# Altium schematic sheet ButterWorth_8th_order_Filter.SchDoc(ButterWorth_8th_order_Filter)
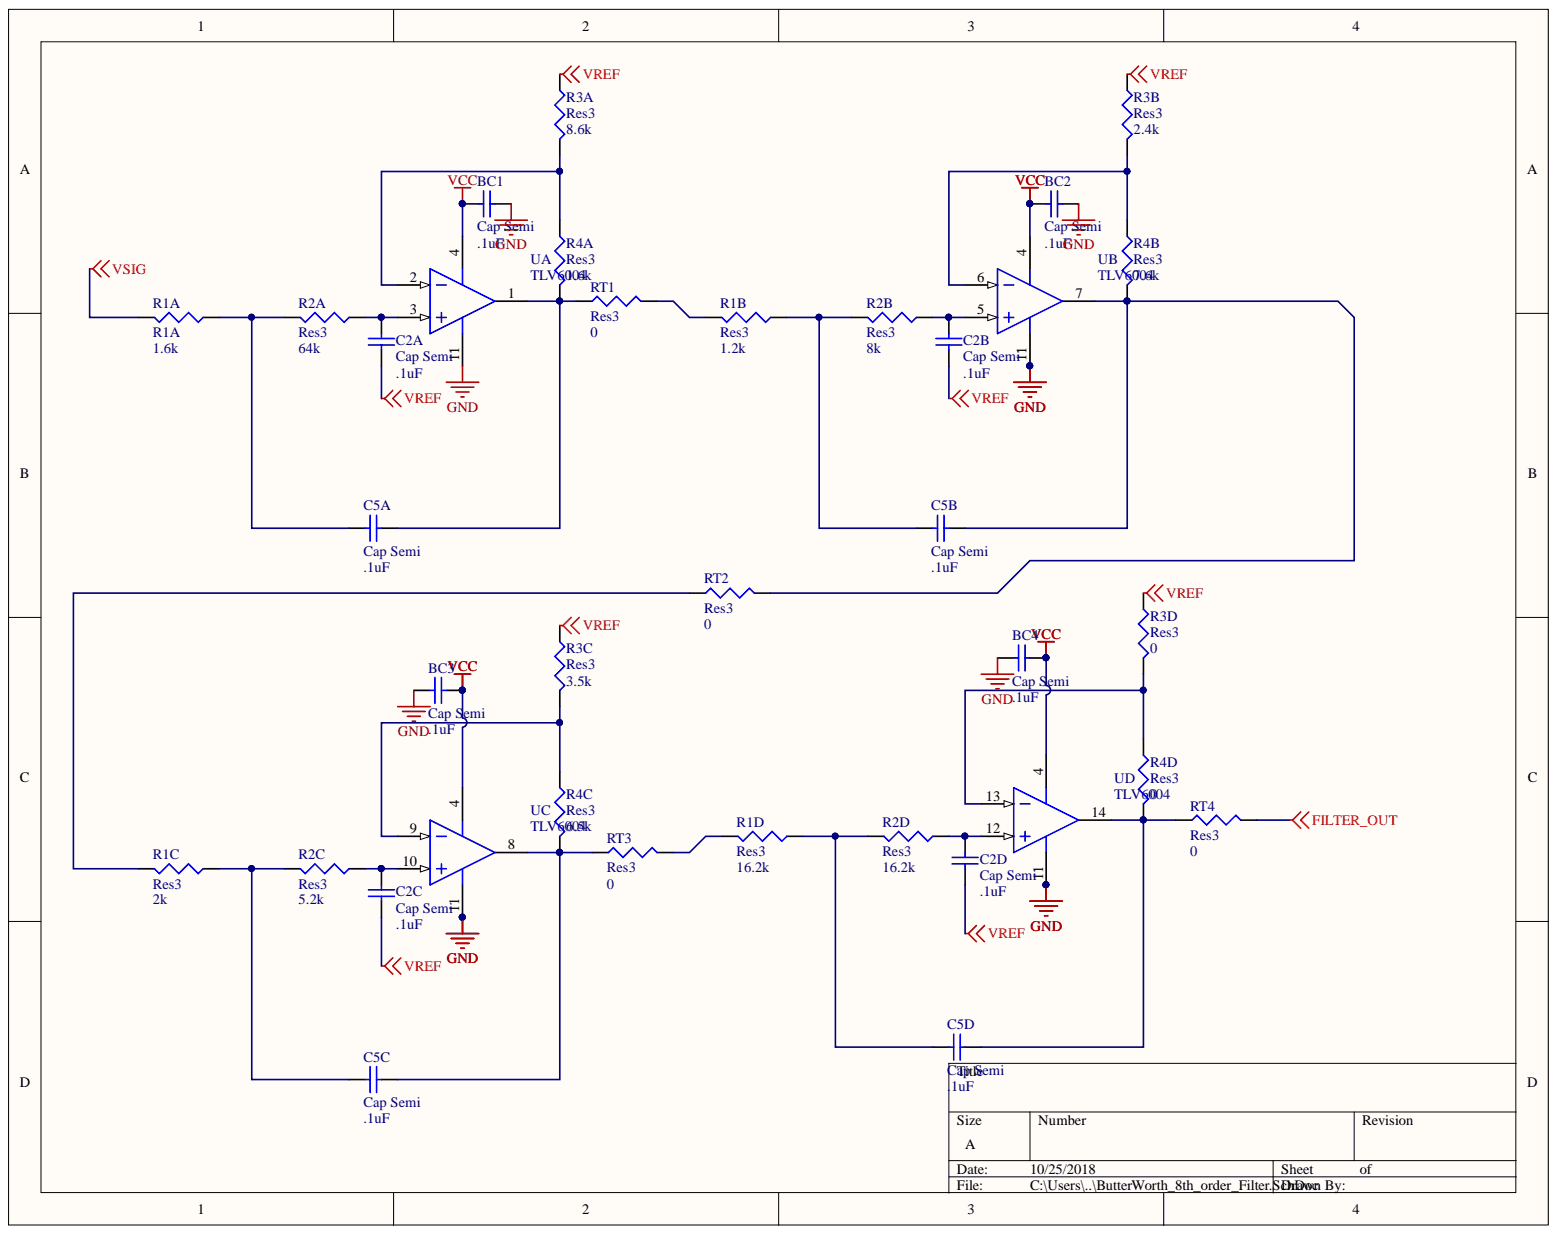
[diagram p0: ButterWorth_8th_order_Filter.SchDoc]

## Parts
BC1  Cap Semi  value .1uF  [1608[0603]]
BC2  Cap Semi  value .1uF  [1608[0603]]
BC3  Cap Semi  value .1uF  [1608[0603]]
BC4  Cap Semi  value .1uF  [1608[0603]]
C2A  Cap Semi  value .1uF  [1608[0603]]
C2B  Cap Semi  value .1uF  [1608[0603]]
C2C  Cap Semi  value .1uF  [1608[0603]]
C2D  Cap Semi  value .1uF  [1608[0603]]
C5A  Cap Semi  value .1uF  [1608[0603]]
C5B  Cap Semi  value .1uF  [1608[0603]]
C5C  Cap Semi  value .1uF  [1608[0603]]
C5D  Cap Semi  value .1uF  [1608[0603]]
R1A  R1A  value 1.6k  [J1-0603]
R1B  Res3  value 1.2k  [J1-0603]
R1C  Res3  value 2k  [J1-0603]
R1D  Res3  value 16.2k  [J1-0603]
R2A  Res3  value 64k  [J1-0603]
R2B  Res3  value 8k  [J1-0603]
R2C  Res3  value 5.2k  [J1-0603]
R2D  Res3  value 16.2k  [J1-0603]
R3A  Res3  value 8.6k  [J1-0603]
R3B  Res3  value 2.4k  [J1-0603]
R3C  Res3  value 3.5k  [J1-0603]
R3D  Res3  value 0  [J1-0603]
R4A  Res3  value 1.6k  [J1-0603]
R4B  Res3  value 7.6k  [J1-0603]
R4C  Res3  value 6.5k  [J1-0603]
R4D  Res3  value 0  [J1-0603]
RT1  Res3  value 0  [J1-0603]
RT2  Res3  value 0  [J1-0603]
RT3  Res3  value 0  [J1-0603]
RT4  Res3  value 0  [J1-0603]
U  TLV6004  [SOP65P640X120-14N]  (parts A,B,C,D)

## Nets
FILTER_OUT: RT4.1
GND: BC1.1 BC2.1 BC3.2 BC4.2 U.11
VCC: BC1.2 BC2.2 BC3.1 BC4.1 U.4
VREF: C2A.1 C2B.1 C2C.1 C2D.1 R3A.2 R3B.2 R3C.2 R3D.2
VSIG: R1A.1
NetC2A_2: C2A.2 R2A.2 U.3
NetC2B_2: C2B.2 R2B.2 U.5
NetC2C_2: C2C.2 R2C.2 U.10
NetC2D_2: C2D.2 R2D.2 U.12
NetC5A_1: C5A.1 R4A.1 RT1.2 U.1
NetC5A_2: C5A.2 R1A.2 R2A.1
NetC5B_1: C5B.1 R4B.1 RT2.1 U.7
NetC5B_2: C5B.2 R1B.2 R2B.1
NetC5C_1: C5C.1 R4C.1 RT3.2 U.8
NetC5C_2: C5C.2 R1C.2 R2C.1
NetC5D_1: C5D.1 R4D.1 RT4.2 U.14
NetC5D_2: C5D.2 R1D.2 R2D.1
NetR1B_1: R1B.1 RT1.1
NetR1C_1: R1C.1 RT2.2
NetR1D_1: R1D.1 RT3.1
NetR3A_1: R3A.1 R4A.2 U.2
NetR3B_1: R3B.1 R4B.2 U.6
NetR3C_1: R3C.1 R4C.2 U.9
NetR3D_1: R3D.1 R4D.2 U.13

## Library data of the parts
BC1 = Cap Semi: Capacitor (Semiconductor SIM Model); Value: .1uF; Simulation: CAP; Signal Integrity: Cap Semi; Published: 8-Jun-2000; PackageReference: C1206; PackageDescription: Chip Capacitor; Library Name: Miscellaneous Devices.IntLib; LatestRevisionNote: IPC-7351 Footprint Added.; LatestRevisionDate: 29-May-2009; Component Kind: Standard; Publisher: Altium Limited
BC2-BC4 C2A C2B C2C C2D C5A C5B C5C C5D = Cap Semi: Capacitor (Semiconductor SIM Model); Simulation: CAP; Signal Integrity: Cap Semi; Published: 8-Jun-2000; PackageReference: C1206; PackageDescription: Chip Capacitor; Library Name: Miscellaneous Devices.IntLib; LatestRevisionNote: IPC-7351 Footprint Added.; LatestRevisionDate: 29-May-2009; Component Kind: Standard; Publisher: Altium Limited
R1A R1B R1C R1D R2A R2B R2C R2D R3A R3B R3C R3D R4A R4B R4C R4D RT1-RT4 = Res3: Resistor; Simulation: RESISTOR; Signal Integrity: Res; Published: 8-Jun-2000; PackageReference: J1-0603; PackageDescription: Chip Resistor; Library Name: Miscellaneous Devices.IntLib; LatestRevisionNote: IPC-7351 Footprint Added.; LatestRevisionDate: 29-May-2009; Component Kind: Standard; Publisher: Altium Limited
U = LP2901D: Low Power Quad Differential Comparator; Simulation: LP2901; Published: 16-Jan-2001; PCB3D: D014; PackageVersion: Jan-1998; PackageReference: D014; PackageDescription: 14-Pin Small Outline Integrated Circuit 1.27 mm Pitch; Library Name: TI Analog Comparator.IntLib; LatestRevisionNote: IPC-7351 Footprint Added.; LatestRevisionDate: 13-Apr-2006; DatasheetVersion: May-1988; ComponentLink2URL: http://www-s.ti.com/sc/ds/lp2901.pdf; ComponentLink2Description: Datasheet; ComponentLink1URL: http://www.ti.com/; ComponentLink1Description: Manufacturer Link; Component Kind: Standard; Code_IPC: SOIC127P600-14; Publisher: Altium Limited
